# Altium schematic sheet 5.04.51805A02_STMPower.SchDoc(5.04.51805A02_STMPower)
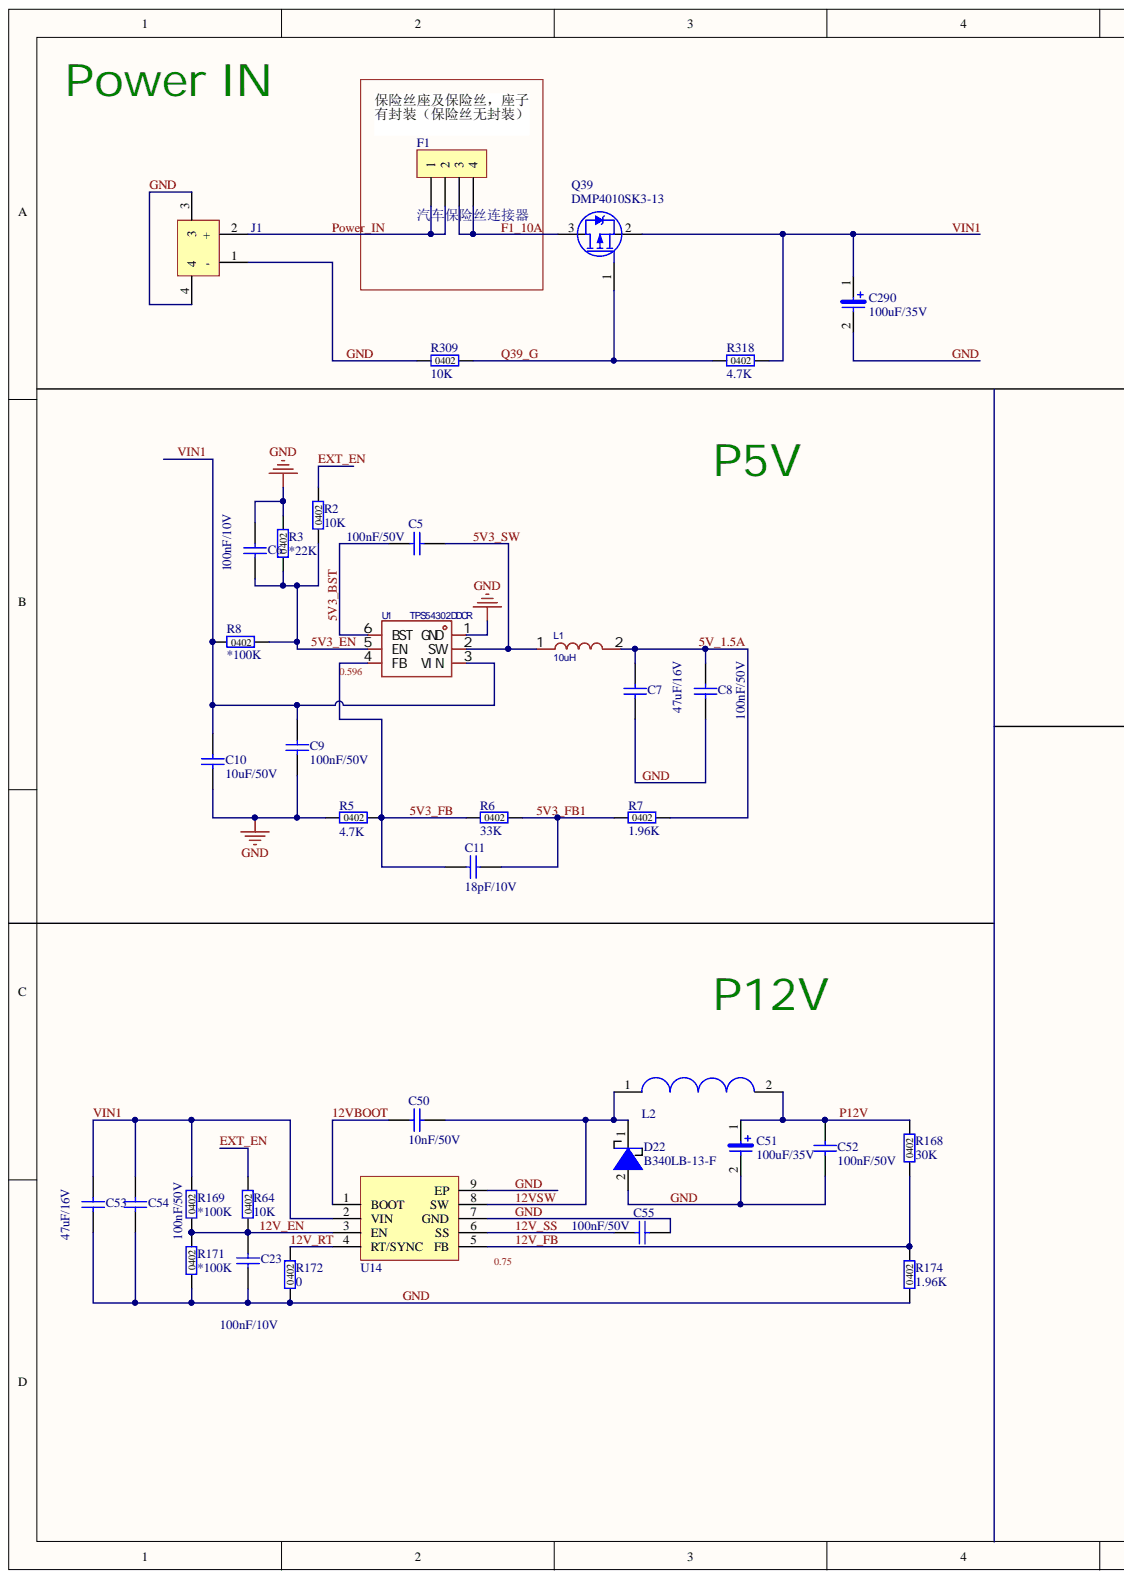
[diagram p0: 5.04.51805A02_STMPower.SchDoc, left]
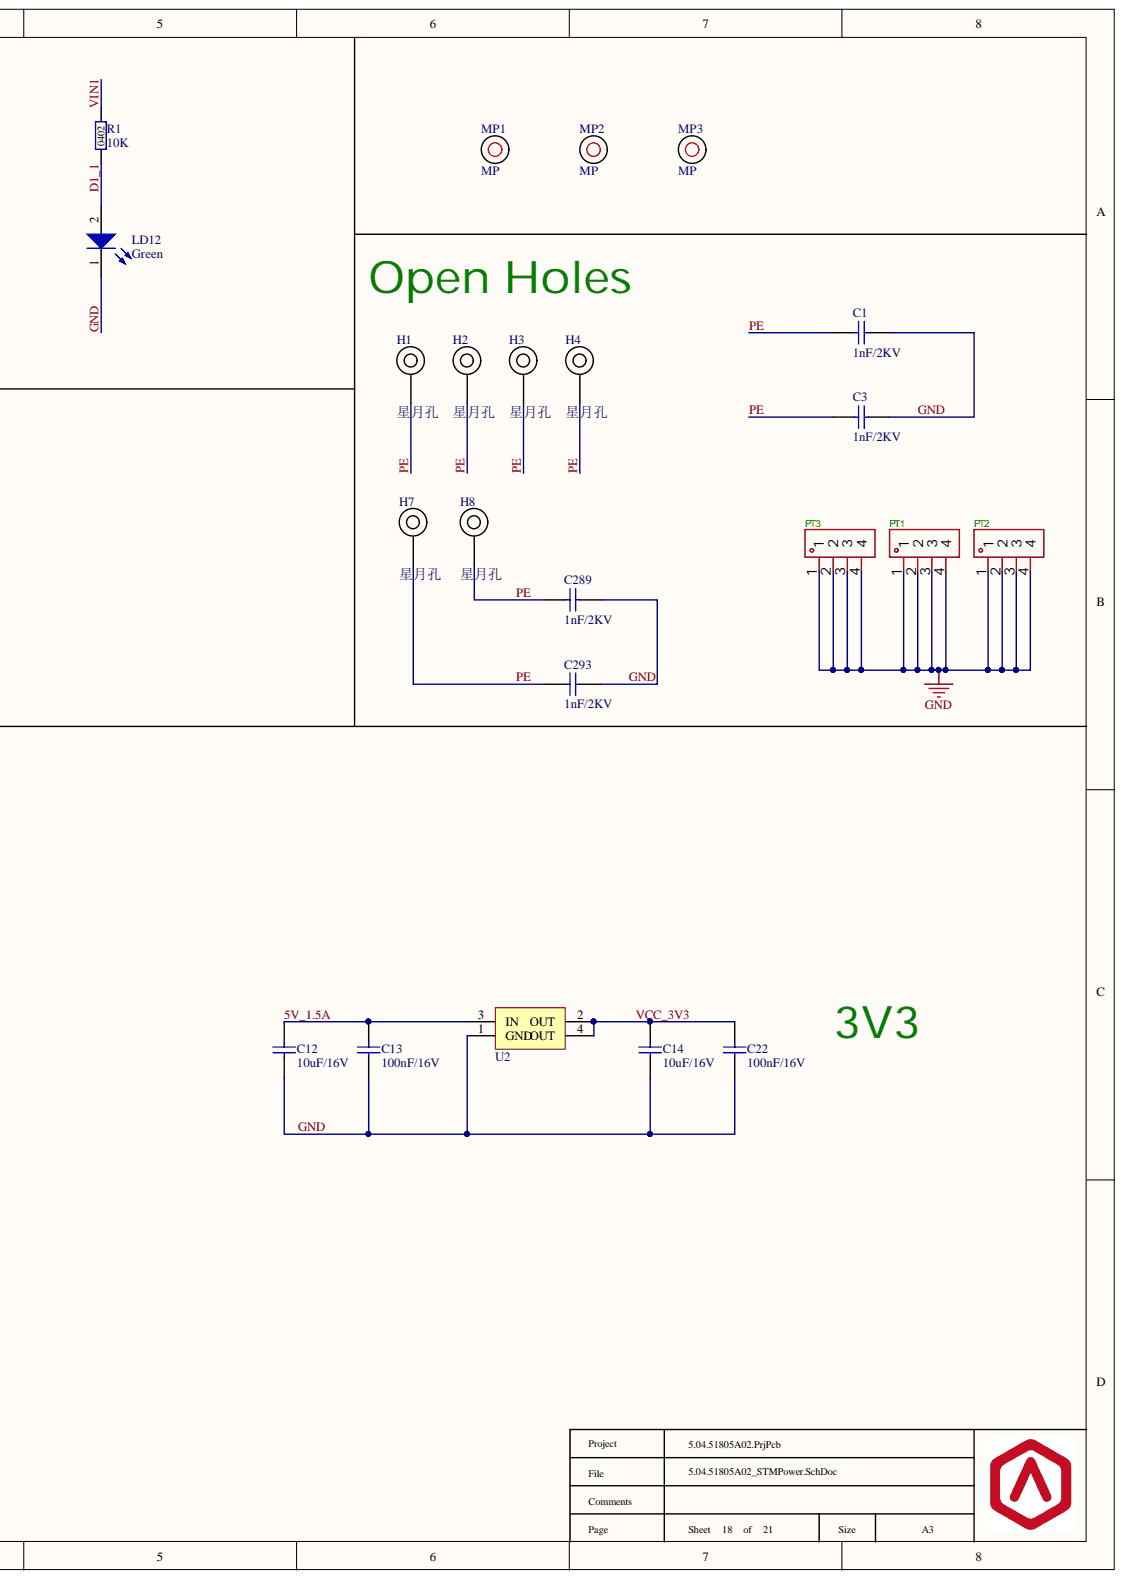
[diagram p1: 5.04.51805A02_STMPower.SchDoc, right]

## Parts
C1  1nF/2KV  [C1206]
C3  1nF/2KV  [C1206]
C5  100nF/50V  [C0603]
C6  100nF/10V  [C0402]
C7  47uF/16V  [C1210]
C8  100nF/50V  [C0603]
C9  100nF/50V  [C0603]
C10  10uF/50V  [C0805]
C11  18pF/10V  [C0402]
C12  10uF/16V  [C0603]
C13  100nF/16V  [C0402]
C14  10uF/16V  [C0603]
C22  100nF/16V  [C0402]
C23  100nF/10V  [C0402]
C50  10nF/50V  [C0402]
C51  100uF/35V  [ALC_260_260_307]
C52  100nF/50V  [C0603]
C53  47uF/16V  [C1210]
C54  100nF/50V  [C0603]
C55  100nF/50V  [C0603]
C289  1nF/2KV  [C1206]
C290  100uF/35V  [ALC_260_260_307]
C293  1nF/2KV  [C1206]
D22  B340LB-13-F  [SMB]
F1  汽车保险丝连接器  [汽车保险丝座3544-2]
H1  星月孔  [PTH_700_425_M]
H2  星月孔  [PTH_700_425_M]
H3  星月孔  [PTH_700_425_M]
H4  星月孔  [PTH_700_425_M]
H7  星月孔  [PTH_700_425_M]
H8  星月孔  [PTH_700_425_M]
J1  XT60PW-M  [CONN-TH_XT60PW-M]  (value not drawn)
L1  10uH  [IND-SMD_L5.4-W5.2_FXL0530]
L2  SMMS1040-220M  [IND-SMD_L11.5-W10.0_SMMS1040]  (value not drawn)
LD12  Green  [LED0603_2]
MP1  MP  [MARK]
MP2  MP  [MARK]
MP3  MP  [MARK]
PT1  SMTSO3050CTJ  [SMD_SMTSO3060CTJ]  (value not drawn)
PT2  SMTSO3050CTJ  [SMD_SMTSO3060CTJ]  (value not drawn)
PT3  SMTSO2530CTJ  [SMD_BD5.6-L5.6-W5.6-D4.1]  (value not drawn)
Q39  DMP4010SK3-13  [TO-252-3]
R1  10K  [R0402]
R2  10K  [R0402]
R3  *22K  [R0402]
R5  4.7K  [R0402]
R6  33K  [R0402]
R7  1.96K  [R0402]
R8  *100K  [R0402]
R64  10K  [R0402]
R168  30K  [R0402]
R169  *100K  [R0402]
R171  *100K  [R0402]
R172  0  [R0402]
R174  1.96K  [R0402]
R309  10K  [R0402]
R318  4.7K  [R0402]
U1  TPS54302DDCR  [TSOT-23-6_L2.9-W1.6-P0.95-LS2.8-BL]
U2  TLV1117LV33DCYR  [SOT-223_L6.7-W3.5-P2.30-BR]  (value not drawn)
U14  LMR14050SDDAR  [SOIC-8_L4.9-W3.9-P1.27-LS6.0-BL-EP]  (value not drawn)

## Nets
5V3_BST: C5.1 U1.6(BST)
5V3_EN: C6.1 R2.1 R3.1 R8.2 U1.5(EN)
5V3_FB: C11.1 R5.2 R6.1 U1.4(FB)
5V3_FB1: C11.2 R6.2 R7.1
5V3_SW: C5.2 L1.1 U1.2(SW)
5V_1.5A: C7.2 C8.2 C12.2 C13.2 L1.2 R7.2 U2.3(IN)
12V_EN: C23.2 R64.1 R169.1 R171.2 U14.3(EN)
12V_FB: R168.1 R174.2 U14.5(FB)
12V_RT: R172.2 U14.4(RT/SYNC)
12V_SS: C55.1 U14.6(SS)
12VBOOT: C50.1 U14.1(BOOT)
12VSW: C50.2 D22.1 L2.1 U14.8(SW)
D1_1: LD12.2 R1.1
EXT_EN: R2.2 R64.2
F1_10A: F1.3 F1.4 Q39.3
GND: C1.2 C3.2 C6.2 C7.1 C8.1 C9.1 C10.1 C12.1 C13.1 C14.1 C22.1 C23.1 C51.2 C52.1 C53.1 C54.1 C55.2 C289.2 C290.2 C293.2 D22.2 J1.1(-) J1.3 J1.4 LD12.1 PT1.1 PT1.2 PT1.3 PT1.4 PT2.1 PT2.2 PT2.3 PT2.4 PT3.1 PT3.2 PT3.3 PT3.4 R3.2 R5.1 R171.1 R172.1 R174.1 R309.1 U1.1(GND) U2.1(GND) U14.7(GND) U14.9(EP)
P12V: C51.1 C52.2 L2.2 R168.2
PE: C1.1 C3.1 C289.1 C293.1 H1.0 H2.0 H3.0 H4.0 H7.0 H8.0
POWER_IN: F1.1 F1.2 J1.2(+)
Q39_G: Q39.1 R309.2 R318.1
VCC_3V3: C14.2 C22.2 U2.2(OUT) U2.4(OUT)
VIN1: C9.2 C10.2 C53.2 C54.2 C290.1 Q39.2 R1.2 R8.1 R169.2 R318.2 U1.3(VIN) U14.2(VIN)
N00966:

## Library data of the parts
C1 C3 C289 C293 = C1206_1nF_2KV: Ceramic Capacitor, 1nF, ±10%, 2KV, -55°C~125°C, C1206; Manufacturer1: Yageo; ManufacturerPartNumber1: CC1206KKX7RDBB102; Code: 51A4C102; Component Kind: Standard; Library Name: Raise3D_Capacitor.IntLib
C5 C8 C9 C52 C54 C55 = C0603_100nF_50V: Ceramic Capacitor, 100nF, ±10%, 50V, -55°C~125°C, C0603; Manufacturer1: Yageo; ManufacturerPartNumber1: CC0603KRX7R9BB104; Code: 5192C104; Component Kind: Standard; Library Name: Raise3D_Capacitor.IntLib
C6 C23 = C0402_100nF_10V: Ceramic Capacitor, 100nF, ±10%, 10V, -55°C~125°C, C0402; Manufacturer1: MuRata; ManufacturerPartNumber1: GRM155R71A104KA01D; Code: 5161C104; Component Kind: Standard; Library Name: Raise3D_Capacitor.IntLib
C7 C53 = C1210_47uF_16V: Ceramic Capacitor, 47uF, ±10%, 16V, -55°C~85°C, C1210; Manufacturer1: MuRata; ManufacturerPartNumber1: GRM32ER61C476KE15L; Code: 5175C476; Component Kind: Standard; Library Name: Raise3D_Capacitor.IntLib
C10 = C0805_10uF_50V: Ceramic Capacitor, 10uF, ±10%, 50V, -55°C~85°C, C0805; Manufacturer1: Yageo; ManufacturerPartNumber1: CC0805KKX5R9BB106; Code: 5193C106; Component Kind: Standard; Library Name: Raise3D_Capacitor.IntLib
C11 = C0402_18pF_10V: Ceramic Capacitor, 18pF, ±5%, 10V, -55°C~125°C, C0402; Manufacturer1: KEMET; ManufacturerPartNumber1: C0402C180J8GAC7867; Code: 5161C180; Component Kind: Standard; Library Name: Raise3D_Capacitor.IntLib
C12 C14 = C0603_10uF_16V: Ceramic Capacitor, 10uF, ±10%, 16V, -55°C~85°C, C0603; Manufacturer1: SAMSUNG; ManufacturerPartNumber1: CL10A106KO8NQNC; Code: 5172C106; Component Kind: Standard; Library Name: Raise3D_Capacitor.IntLib
C13 C22 = C0402_100nF_16V: Ceramic Capacitor, 100nF, ±10%, 16V, -55°C~125°C, C0402; Manufacturer1: Yageo; ManufacturerPartNumber1: CC0402KPX7R7BB104; Code: 5171C104; Component Kind: Standard; Library Name: Raise3D_Capacitor.IntLib
C50 = C0402_10nF/50V: Ceramic Capacitor, 10nF, ±10%, 50V, -55°C~125°C, C0402; Manufacturer1: Samsung; ManufacturerPartNumber1: CL05B103KB5NFNC; Code: 5191C104; Component Kind: Standard; Manufacturer2: TDK; ManufacturerPartNumber2: CGA2B3X7R1H103K050BB; Library Name: Raise3D_Capacitor.IntLib
C51 C290 = ALC_100uF_35V: Aluminum Electrolytic Capacitors, 100uF, ±20%, 35V, -55°C~105°C, ALC_660_660_780; Manufacturer1: Panasonic; ManufacturerPartNumber1: EEE-FK1V101XP; Code: 51B7C107; Component Kind: Standard; Library Name: Raise3D_Capacitor.IntLib
D22 = SBD_B340LB-13-F: SBD,B340LB-13-F,40V,450mV,3A,SMB,-55°C~125°C; Manufacturer1: Diodes; Code: 53030006; Component Kind: Standard; ManufacturerPartNumber1: B340LB-13-F; Library Name: Raise3D_Diode.IntLib
F1 = JS_3544-2: Manufacturer1: KeystoneElectronics; ManufacturerPartNumber1: 3544-2; Code: 55040003; Component Kind: Standard; Description: 汽车保险丝连接器; Library Name: Raise3D_Connector.IntLib
H1-H4 H7 H8 = PTH_700_425_M: Component Kind: Standard; Library Name: Raise3D_Mechanic.IntLib
J1 = XT60PW-M: 插头,600V,35A,-20℃~120℃,SMD=7.2mm; Manufacturer1: AMASS; Code: C98732; Component Kind: Standard; ManufacturerPartNumber1: XT60PW-M; Library Name: Raise3D_General.IntLib
L1 = FXL0530-100-M: 3.5A,SMD,5.2x5.4mm,10uH,60mΩ; Manufacturer1: cjiang(长江微电); Component Kind: Standard; ManufacturerPartNumber1: FXL0530-100-M; Library Name: QQQ.IntLib
L2 = SMMS1040-220M: 功率电感,22uH,4A,-40~125℃,11.5*10mm; Manufacturer1: SXN; Code: C149597; Component Kind: Standard; ManufacturerPartNumber1: SMMS1040-220M; Library Name: Raise3D_General.IntLib
LD12 = LED_APT1608CGCK: LED,APT1608CGCK,Green,2.5V,TOP,LED0603,-40°C~85°C; Manufacturer1: Kingbright; Code: 53010003; Component Kind: Standard; ManufacturerPartNumber1: APT1608CGCK; Library Name: Raise3D_Diode.IntLib
MP1-MP3 = MARK: R=1mm; Component Kind: Standard; Library Name: Raise3D_Mechanic.IntLib
PT1 PT2 = SMTSO3050CTJ: M3X5; Manufacturer1: Sinhoo(雄和远景); Component Kind: Standard; ManufacturerPartNumber1: SMTSO3050CTJ; Library Name: QQQ.IntLib
PT3 = SMTSO2530CTJ: M2.5X3; Manufacturer1: Sinhoo(雄和远景); Component Kind: Standard; ManufacturerPartNumber1: SMTSO2530CTJ; Library Name: QQQ.IntLib
Q39 = PMOS_DMP4010SK3-13-ND: P-MOSFET, DMP4010SK3-13-ND, 1Channel, Vds=40V, Id=50A, Vgs=+/-25V, TO-252-3,-55°C~150°C; Manufacturer1: DIODES; Code: 54020003; Component Kind: Standard; ManufacturerPartNumber1: DMP4010SK3-13-ND; Library Name: Raise3D_MOS&BJT.IntLib
R1 R2 R64 R309 = R0402_10K: Resistor 10Kohm,1%,1/16W,R0402; Manufacturer1: Yageo; ManufacturerPartNumber1: RC0402FR-0710KL; Code: 5001010K; Component Kind: Standard; Manufacturer2: Samsung; ManufacturerPartNumber2: RC1005F103CS; Library Name: Raise3D_Resistor.IntLib
R3 = R0402_22K: Resistor 22Kohm,1%,1/16W,R0402; Manufacturer1: Samsung; ManufacturerPartNumber1: RC1005F223CS; Code: 5001022K; Component Kind: Standard; Library Name: Raise3D_Resistor.IntLib
R5 R318 = R0402_4.7K: Resistor 4.7Kohm,5%,1/16W,R0402; Manufacturer1: Yageo; ManufacturerPartNumber1: RC0402JR-074K7L; Code: 501104K7; Component Kind: Standard; Manufacturer2: Samsung; ManufacturerPartNumber2: RCS1005J472CS; Library Name: Raise3D_Resistor.IntLib
R6 = R0402_33K: Resistor 33Kohm,1%,1/16W,R0402; Manufacturer1: Yageo; ManufacturerPartNumber1: RC0402FR-0733KL; Code: 5001033K; Component Kind: Standard; Library Name: Raise3D_Resistor.IntLib
R7 R174 = R0402_1.96K: Resistor 1.96Kohm,1%,1/16W,R0402; Manufacturer1: Yageo; ManufacturerPartNumber1: RC1005F1961CS; Code: 50011K96; Component Kind: Standard; Library Name: Raise3D_Resistor.IntLib
R8 R169 R171 = R0402_100K: Resistor 100Kohm,1%,1/16W,R0402; Manufacturer1: Yageo; ManufacturerPartNumber1: RC0402FR-07100KL; Code: 5001100K; Component Kind: Standard; Manufacturer2: Samsung; ManufacturerPartNumber2: RC1005F104CS; Library Name: Raise3D_Resistor.IntLib
R168 = R0402_30K: Resistor 30Kohm,1%,1/16W,R0402; Manufacturer1: Samsung; ManufacturerPartNumber1: RC1005F303CS; Code: 5001030K; Component Kind: Standard; Library Name: Raise3D_Resistor.IntLib
R172 = R0402_0: Resistor 0ohm,1%,1/16W,R0402; Manufacturer1: Yageo; ManufacturerPartNumber1: RC0402FR-070RL; Code: 5001000R; Component Kind: Standard; Library Name: Raise3D_Resistor.IntLib
U1 = TPS54302DDCR: 28V输入,3A同步降压DC-DC转换器; Manufacturer1: TI; Component Kind: Standard; ManufacturerPartNumber1: TPS54302DDCR; Library Name: QQQ.IntLib
U2 = TLV1117LV33DCYR: LDO,5.5V/3.3V,1A,-45℃~125℃,SOT-223; Manufacturer1: TI; Code: C15578; Component Kind: Standard; Manufacturer2: JSMSEMI; ManufacturerPartNumber1: TLV1117LV33DCYR; ManufacturerPartNumber2: TLV1117LV33DCYR; Library Name: Raise3D_General.IntLib
U14 = LMR14050SDDAR: DC-DC电源芯片,4~40V,800mV~28V,5A,-40℃~125℃,SOIC-8-EP; Manufacturer1: TI; Code: C155484; Component Kind: Standard; ManufacturerPartNumber1: LMR14050SDDAR; Library Name: Raise3D_General.IntLib
